# Altium schematic sheet Sheet.SchDoc
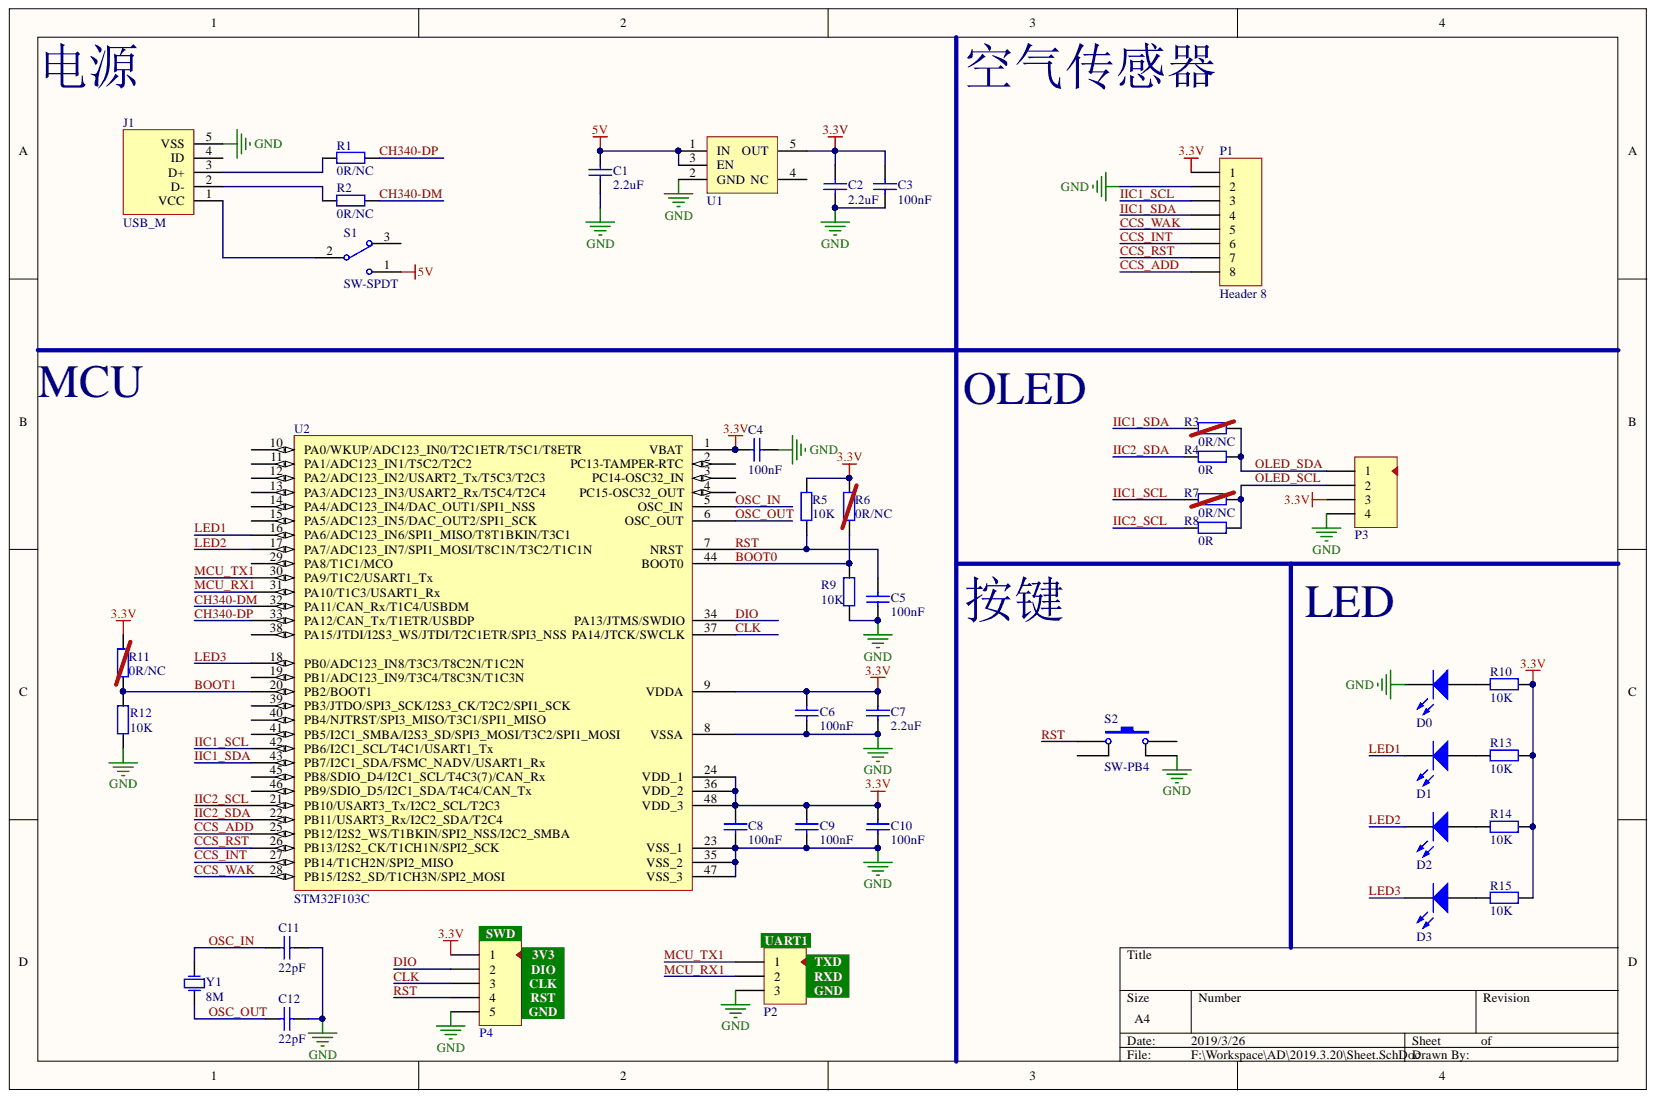
[diagram p0: Sheet.SchDoc]

## Parts
C1  value 2.2uF  [C-0805]  (hidden comment: Cap-S)
C2  value 2.2uF  [C-0805]  (hidden comment: Cap-S)
C3  value 100nF  [C-0805]  (hidden comment: Cap-S)
C4  value 100nF  [C-0805]  (hidden comment: Cap-S)
C5  value 100nF  [C-0805]  (hidden comment: Cap-S)
C6  value 100nF  [C-0805]  (hidden comment: Cap-S)
C7  value 2.2uF  [C-0805]  (hidden comment: Cap-S)
C8  value 100nF  [C-0805]  (hidden comment: Cap-S)
C9  value 100nF  [C-0805]  (hidden comment: Cap-S)
C10  value 100nF  [C-0805]  (hidden comment: Cap-S)
C11  value 22pF  [C-0805]  (hidden comment: Cap-S)
C12  value 22pF  [C-0805]  (hidden comment: Cap-S)
D0  LED0  [LED-0805]  (value not drawn)
D1  LED0  [LED-0805]  (value not drawn)
D2  LED0  [LED-0805]  (value not drawn)
D3  LED0  [LED-0805]  (value not drawn)
J1  USB_M  [USB-MICRO-A01SB141B1-261]
P1  Header 8  [HDR1X8]
P2  Header 3  [HDR3X1-2.54]  (value not drawn)
P3  Header 4  [HDR4X1-2.54]  (value not drawn)
P4  Header 5  [HDR5X1-2.54]  (value not drawn)
R1  value 0R/NC  [0805-1]  (hidden comment: Res1)
R2  value 0R/NC  [0805-1]  (hidden comment: Res1)
R3  value 0R/NC  [0805-1]  (hidden comment: Res1)
R4  value 0R  [0805-1]  (hidden comment: Res1)
R5  value 10K  [0805-1]  (hidden comment: Res1)
R6  value 0R/NC  [0805-1]  (hidden comment: Res1)
R7  value 0R/NC  [0805-1]  (hidden comment: Res1)
R8  value 0R  [0805-1]  (hidden comment: Res1)
R9  value 10K  [0805-1]  (hidden comment: Res1)
R10  value 10K  [0805-1]  (hidden comment: Res1)
R11  value 0R/NC  [0805-1]  (hidden comment: Res1)
R12  value 10K  [0805-1]  (hidden comment: Res1)
R13  value 10K  [0805-1]  (hidden comment: Res1)
R14  value 10K  [0805-1]  (hidden comment: Res1)
R15  value 10K  [0805-1]  (hidden comment: Res1)
S1  SW-SPDT  [SWITCH_A2]
S2  SW-PB4  [SW_PB2_A1_1]
U1  LDO-3.3V  [SOT23-5]  (value not drawn)
U2  STM32F103C  [LQFP-48]
Y1  value 8M  [X-HC-49S]  (hidden comment: XTAL)

## Nets
3.3V: C2.1 C3.1 C4.1 C6.1 C7.1 C8.1 C9.1 C10.1 P1.1 P3.3 P4.1 R5.2 R6.1 R10.1 R11.1 R13.1 R14.1 R15.1 U1.5(OUT) U2.1(VBAT) U2.9(VDDA) U2.24(VDD_1) U2.36(VDD_2) U2.48(VDD_3)
5V: C1.1 S1.1 U1.1(IN) U1.3(EN)
GND: C1.2 C2.2 C3.2 C4.2 C5.2 C6.2 C7.2 C8.2 C9.2 C10.2 C11.2 C12.2 D0.2 J1.5(VSS) P1.2 P2.3 P3.4 P4.5 R9.2 R12.2 S2.3 U1.2(GND) U2.8(VSSA) U2.23(VSS_1) U2.35(VSS_2) U2.47(VSS_3)
BOOT0: R6.2 R9.1 U2.44(BOOT0)
BOOT1: R11.2 R12.1 U2.20(PB2/BOOT1)
CCS_ADD: P1.8 U2.25(PB12/I2S2_WS/T1BKIN/SPI2_NSS/I2C2_SMBA)
CCS_INT: P1.6 U2.27(PB14/T1CH2N/SPI2_MISO)
CCS_RST: P1.7 U2.26(PB13/I2S2_CK/T1CH1N/SPI2_SCK)
CCS_WAK: P1.5 U2.28(PB15/I2S2_SD/T1CH3N/SPI2_MOSI)
CH340-DM: R2.2 U2.32(PA11/CAN_Rx/T1C4/USBDM)
CH340-DP: R1.2 U2.33(PA12/CAN_Tx/T1ETR/USBDP)
CLK: P4.3 U2.37(PA14/JTCK/SWCLK)
DIO: P4.2 U2.34(PA13/JTMS/SWDIO)
IIC1_SCL: P1.3 R7.1 U2.42(PB6/I2C1_SCL/T4C1/USART1_Tx)
IIC1_SDA: P1.4 R3.1 U2.43(PB7/I2C1_SDA/FSMC_NADV/USART1_Rx)
IIC2_SCL: R8.1 U2.21(PB10/USART3_Tx/I2C2_SCL/T2C3)
IIC2_SDA: R4.1 U2.22(PB11/USART3_Rx/I2C2_SDA/T2C4)
LED1: D1.2 U2.16(PA6/ADC123_IN6/SPI1_MISO/T8T1BKIN/T3C1)
LED2: D2.2 U2.17(PA7/ADC123_IN7/SPI1_MOSI/T8C1N/T3C2/T1C1N)
LED3: D3.2 U2.18(PB0/ADC123_IN8/T3C3/T8C2N/T1C2N)
MCU_RX1: P2.2 U2.31(PA10/T1C3/USART1_Rx)
MCU_TX1: P2.1 U2.30(PA9/T1C2/USART1_Tx)
OLED_SCL: P3.2 R7.2 R8.2
OLED_SDA: P3.1 R3.2 R4.2
OSC_IN: C11.1 U2.5(OSC_IN) Y1.2
OSC_OUT: C12.1 U2.6(OSC_OUT) Y1.1
RST: C5.1 P4.4 R5.1 S2.1 U2.7(NRST)
NetD0_1: D0.1 R10.2
NetD1_1: D1.1 R13.2
NetD2_1: D2.1 R14.2
NetD3_1: D3.1 R15.2
NetJ1_1: J1.1(VCC) S1.2
NetJ1_2: J1.2(D-) R2.1
NetJ1_3: J1.3(D+) R1.1

Not connected: J1 4(ID); S1 3; S2 2 4; U1 4(NC); U2 2(PC13-TAMPER-RTC) 3(PC14-OSC32_IN) 4(PC15-OSC32_OUT) 10(PA0/WKUP/ADC123_IN0/T2C1ETR/T5C1/T8ETR) 11(PA1/ADC123_IN1/T5C2/T2C2) 12(PA2/ADC123_IN2/USART2_Tx/T5C3/T2C3) 13(PA3/ADC123_IN3/USART2_Rx/T5C4/T2C4) 14(PA4/ADC123_IN4/DAC_OUT1/SPI1_NSS) 15(PA5/ADC123_IN5/DAC_OUT2/SPI1_SCK) 19(PB1/ADC123_IN9/T3C4/T8C3N/T1C3N) 29(PA8/T1C1/MCO) 38(PA15/JTDI/I2S3_WS/JTDI/T2C1ETR/SPI3_NSS) 39(PB3/JTDO/SPI3_SCK/I2S3_CK/T2C2/SPI1_SCK) 40(PB4/NJTRST/SPI3_MISO/T3C1/SPI1_MISO) 41(PB5/I2C1_SMBA/I2S3_SD/SPI3_MOSI/T3C2/SPI1_MOSI) 45(PB8/SDIO_D4/I2C1_SCL/T4C3(7)/CAN_Rx) 46(PB9/SDIO_D5/I2C1_SDA/T4C4/CAN_Tx)

## Library data of the parts
C1-C12 = Cap-S: 贴片瓷片电容; Component Kind: Standard; LatestRevisionDate: 17-Jul-2002; LatestRevisionNote: Re-released for DXP Platform.; PackageReference: RAD-0.3; Published: 8-Jun-2000; Library Name: Fszhang.IntLib; Publisher: Altium Limited
D0-D3 = LED0: 发光二极管; Component Kind: Standard; LatestRevisionDate: 08-Jul-2005; LatestRevisionNote: Mech Layer 1 removed.; PackageReference: LED-0; Published: 8-Jun-2000; Library Name: Fszhang-DU.IntLib; Publisher: Altium Limited
J1 = USB_M: Micro/Mini USB; Rule: Width Constraint [Pref Width = 20mil    Min Width = 15mil    Max Width = 30mil]; Rule: Clearance Constraint [Clearance = 8mil]; Component Kind: Standard; Library Name: interface.IntLib
P1 = Header 8: Header, 8-Pin; Component Kind: Standard; LatestRevisionDate: 17-Jul-2002; LatestRevisionNote: Re-released for DXP Platform.; Library Name: Miscellaneous Connectors.IntLib; Publisher: Altium Limited
P2 = Header 3: 接插件; Component Kind: Standard; LatestRevisionDate: 17-Jul-2002; LatestRevisionNote: Re-released for DXP Platform.; Library Name: interface.IntLib; Publisher: Altium Limited
P3 = Header 4: 接插件; Component Kind: Standard; LatestRevisionDate: 17-Jul-2002; LatestRevisionNote: Re-released for DXP Platform.; Library Name: interface.IntLib; Publisher: Altium Limited
P4 = Header 5: 接插件; Component Kind: Standard; LatestRevisionDate: 17-Jul-2002; LatestRevisionNote: Re-released for DXP Platform.; Library Name: interface-DU.IntLib; Publisher: Altium Limited
R1-R15 = Res1: 贴片电阻; Component Kind: Standard; LatestRevisionDate: 17-Jul-2002; LatestRevisionNote: Re-released for DXP Platform.; PackageReference: AXIAL-0.4; Published: 8-Jun-2000; Library Name: Fszhang.IntLib; Publisher: Altium Limited
S1 = SW-SPDT: 开关; Component Kind: Standard; ComponentLink1Description: Manufacturer Link; ComponentLink1URL: http://www.apem.com; DatasheetDocument: 05-Dec-2000; LatestRevisionDate: 17-Dec-2003; LatestRevisionNote: Schematic revised, PCB Footprint replaced.; PackageReference: TL36WW15050; Published: 24-Mar-1999; Library Name: Fszhang-DU.IntLib; Publisher: Altium Limited
S2 = SW-PB4: 微动开关; Component Kind: Standard; LatestRevisionDate: 17-Jul-2002; LatestRevisionNote: Re-released for DXP Platform.; PackageReference: SPST-2; Published: 24-Mar-1999; Library Name: Fszhang-DU.IntLib; Publisher: Altium Limited
U1 = LDO: 稳压芯片; Value: SPX3819 3.3V; Component Kind: Standard; Library Name: Fszhang.IntLib
U2 = STM32F103C: STM32单片机48引脚; Component Kind: Standard; Library Name: Fszhang.IntLib
Y1 = XTAL: 晶振; Component Kind: Standard; LatestRevisionDate: 17-Jul-2002; LatestRevisionNote: Re-released for DXP Platform.; PackageReference: R38; Published: 8-Jun-2000; Library Name: Fszhang.IntLib; Publisher: Altium Limited
